# Altium schematic sheet Wifi.SchDoc(Wifi)
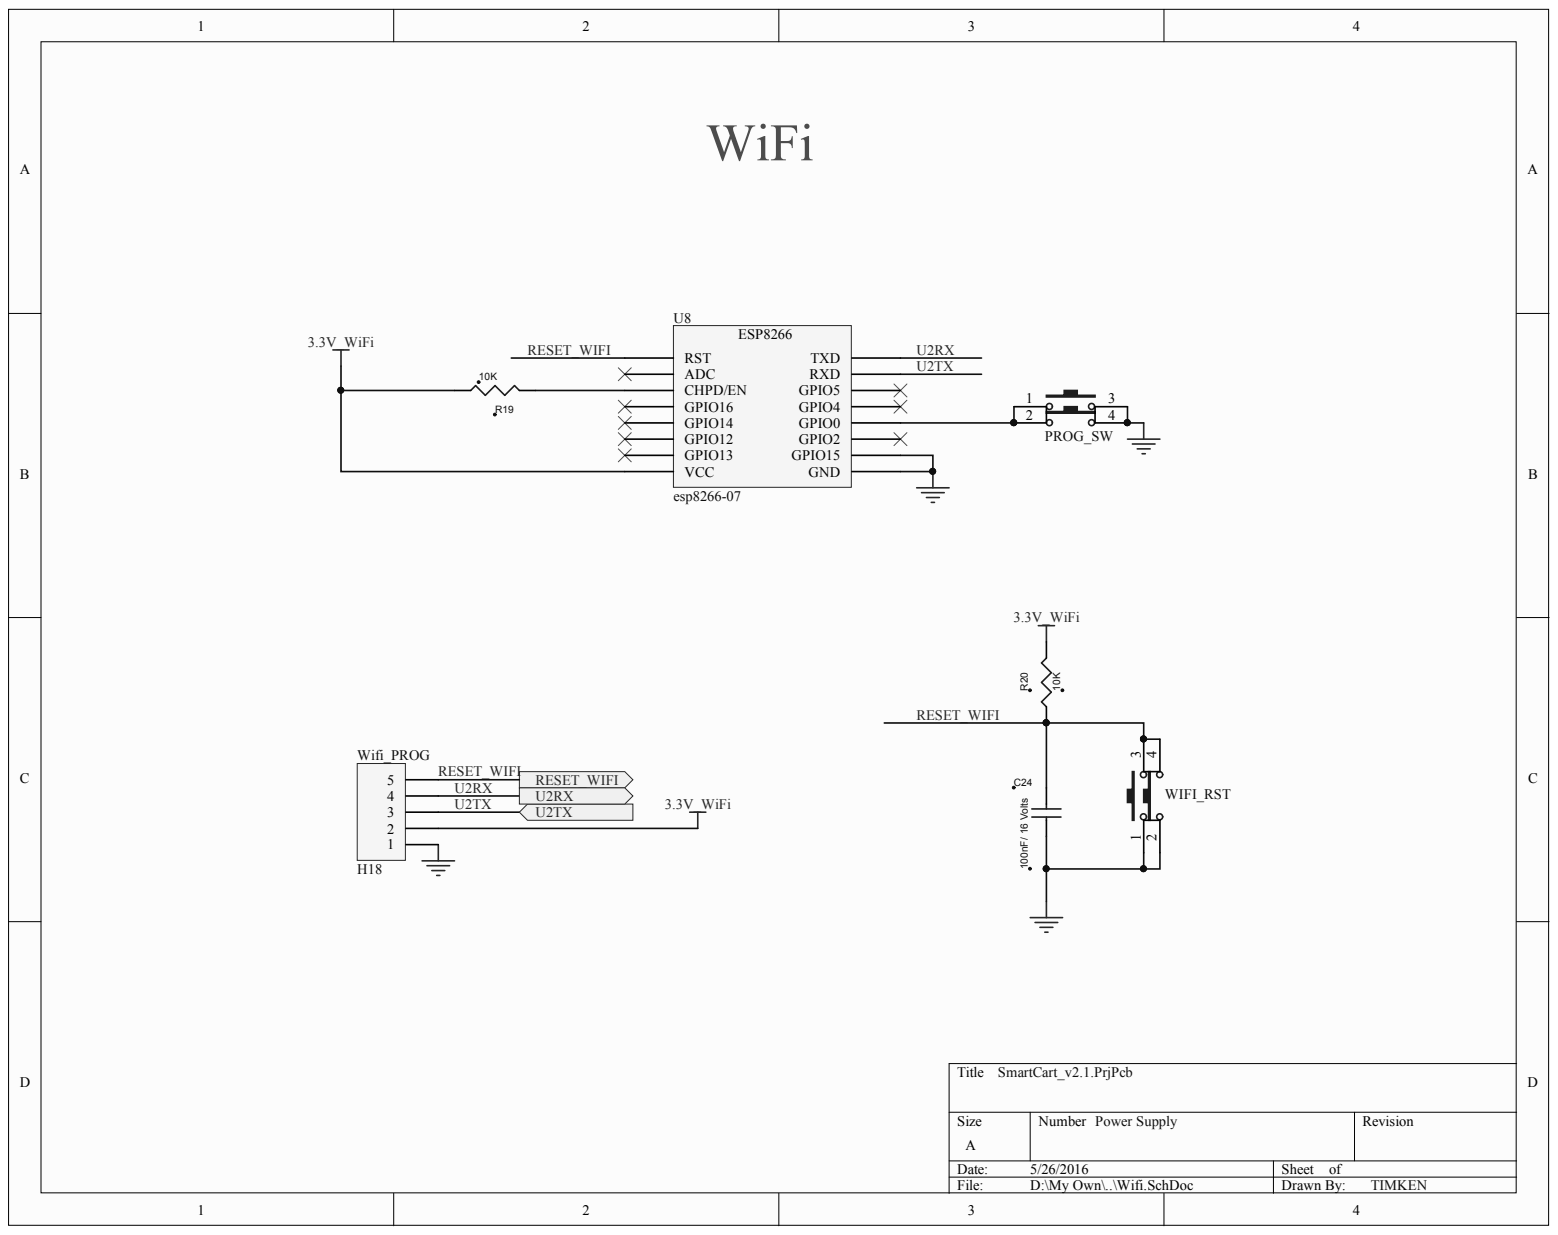
[diagram p0: Wifi.SchDoc]

## Parts
C24  100nF/ 16 Volts  [C0805]
PROG_SW  SW-SMD  [6.60mm x 6.00mm  Outlile(Refer Datasheet)]  (value not drawn)
R19  10K  [0.079" L x 0.049" W (2.00mm x 1.25mm)  (0805 (2012 Metric)  )]
R20  10K  [0.079" L x 0.049" W (2.00mm x 1.25mm)  (0805 (2012 Metric)  )]
U8  esp8266-07  [esp8266-07]
Wifi_PROG  H18  [1x5_single]
WIFI_RST  SW-SMD  [6.60mm x 6.00mm  Outlile(Refer Datasheet)]  (value not drawn)

## Ports
RESET_WIFI, U2RX, U2TX

## Nets
3.3V_WIFI: R19.1 R20.2 U8.7(VCC) Wifi_PROG.2
GND_POWER: C24.1 PROG_SW.3 PROG_SW.4 U8.8(GND) U8.9(GPIO15) Wifi_PROG.1 WIFI_RST.1 WIFI_RST.2
RESET_WIFI: C24.2 R20.1 U8.0(RST) Wifi_PROG.5 WIFI_RST.3 WIFI_RST.4 ; port RESET_WIFI
U2RX: U8.15(TXD) Wifi_PROG.4 ; port U2RX
U2TX: U8.14(RXD) Wifi_PROG.3 ; port U2TX
NetPROG_SW_1: PROG_SW.1 PROG_SW.2 U8.11(GPIO0)
NetR19_2: R19.2 U8.2(CHPD/EN)

Not connected: U8 1(ADC) 3(GPIO16) 4(GPIO14) 5(GPIO12) 6(GPIO13) 10(GPIO2) 12(GPIO4) 13(GPIO5)

## Library data of the parts
C24 = CAP NP: Descr: CAPACITOR, 0805, 100NF, 16V, X7R; M P No: CC0805KRX7R7BB104; Vendor: Farnell; V P No: 644160RL; Component Kind: Standard; Library Name: combined_cart_schematic_lib.SchLib
PROG_SW WIFI_RST = SW-SMD: Switch; Descr: SWITCH TACTILE SPST-NO 0.05A 24V; M P No: B3S-1000P; Vendor: Digikey; V P No: SW836CT-ND; Component Kind: Standard; LatestRevisionDate: 17-Jul-2002; LatestRevisionNote: Re-released for DXP Platform.; PackageDescription: Switch; 2 Leads; PackageReference: SPST-2; Published: 24-Mar-1999; Library Name: combined_cart_schematic_lib.SchLib; Publisher: Altium Limited
R19 R20 = RESISTOR: Descr: RES 10K OHM 1/2W 5% 0805 SMD; M P No: ERJ-P06J103V; Vendor: Digikey; V P No: P10KADTR-ND; Component Kind: Standard; Library Name: combined_cart_schematic_lib.SchLib
U8 = esp8266-07: 4-Bit Loadable Cascadable Binary Counter with Clock Enable and Asynchronous Clear, Single Pin Version; ArchiveName: GENERIC; ClockTrigger: Rising; Enable: High; Loadable: True; PortSize: 4; VHDLIB_SIM: GENERIC_LIB; XilinxEquivalent: CB4CLE; Component Kind: Standard; ComponentLink1Description: Core &Resource Usage; ComponentLink1URL: CR0130 FPGA Counter Resource Usage.pdf#page=18; FunctionalClass: Counter; HelpURL: CR0118 FPGA Generic Library Guide.pdf#page=130; LastRevisionNo: 1.00.00; PortType: Single Pin Version; Published: 29-Jan-2004; Reset: Asynchronous; SubClass: Binary; Library Name: combined_cart_schematic_lib.SchLib; Publisher: Altium Limited
Wifi_PROG = Header 5: Header, 5-Pin; Component Kind: Standard; LatestRevisionDate: 17-Jul-2002; LatestRevisionNote: Re-released for DXP Platform.; Library Name: combined_cart_schematic_lib.SchLib; Publisher: Altium Limited
